# Altium schematic sheet 04-Balancing.SchDoc
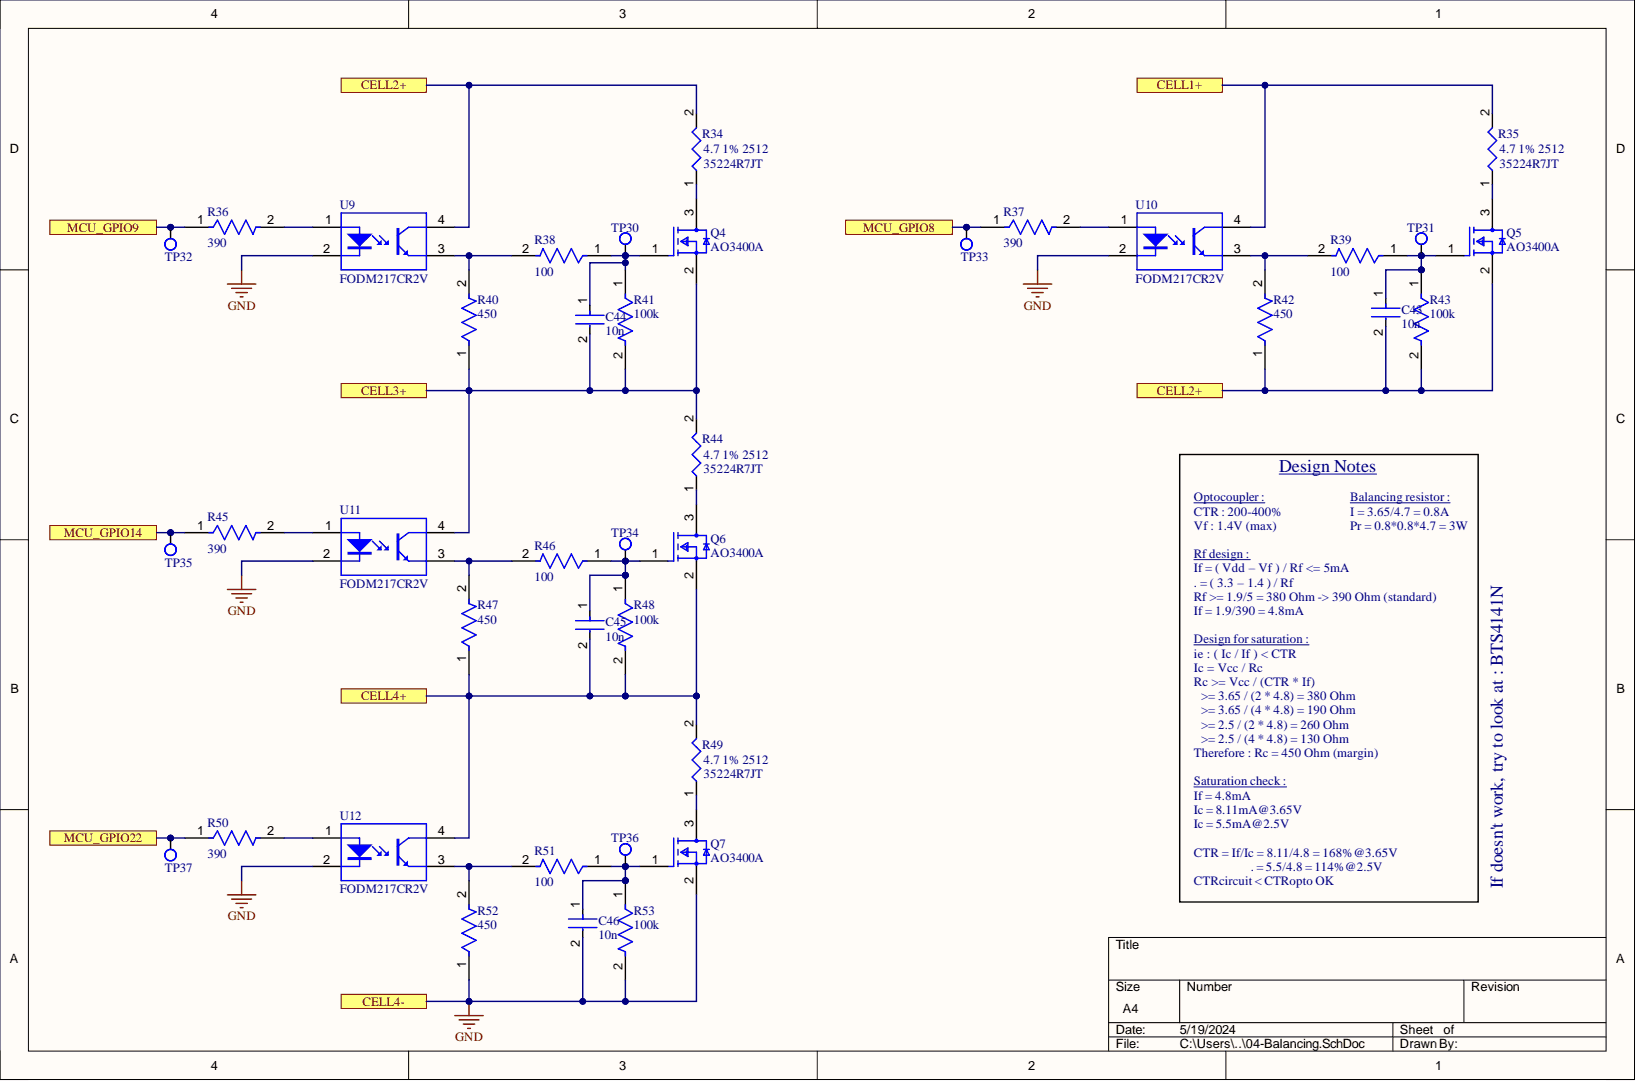
[diagram p0: 04-Balancing.SchDoc]

## Parts
C43  10n  [FP-CC0805-0_85-MFG]
C44  10n  [FP-CC0805-0_85-MFG]
C45  10n  [FP-CC0805-0_85-MFG]
C46  10n  [FP-CC0805-0_85-MFG]
Q4  AO3400A  [FP-SOT23-MFG]
Q5  AO3400A  [FP-SOT23-MFG]
Q6  AO3400A  [FP-SOT23-MFG]
Q7  AO3400A  [FP-SOT23-MFG]
R34  35224R7JT  value 4.7 1% 2512  [RESC6432X06N]
R35  35224R7JT  value 4.7 1% 2512  [RESC6432X06N]
R36  390  [FP-RT0805-MFG]
R37  390  [FP-RT0805-MFG]
R38  100  [FP-RT0805-MFG]
R39  100  [FP-RT0805-MFG]
R40  450  [FP-RT0805-MFG]
R41  100k  [FP-RT0805-MFG]
R42  450  [FP-RT0805-MFG]
R43  100k  [FP-RT0805-MFG]
R44  35224R7JT  value 4.7 1% 2512  [RESC6432X06N]
R45  390  [FP-RT0805-MFG]
R46  100  [FP-RT0805-MFG]
R47  450  [FP-RT0805-MFG]
R48  100k  [FP-RT0805-MFG]
R49  35224R7JT  value 4.7 1% 2512  [RESC6432X06N]
R50  390  [FP-RT0805-MFG]
R51  100  [FP-RT0805-MFG]
R52  450  [FP-RT0805-MFG]
R53  100k  [FP-RT0805-MFG]
TP30  Test point  [Test point-Footprint-1]  (value not drawn)
TP31  Test point  [Test point-Footprint-1]  (value not drawn)
TP32  Test point  [Test point-Footprint-1]  (value not drawn)
TP33  Test point  [Test point-Footprint-1]  (value not drawn)
TP34  Test point  [Test point-Footprint-1]  (value not drawn)
TP35  Test point  [Test point-Footprint-1]  (value not drawn)
TP36  Test point  [Test point-Footprint-1]  (value not drawn)
TP37  Test point  [Test point-Footprint-1]  (value not drawn)
U9  FODM217CR2V  [SOP127P680X239-4N]
U10  FODM217CR2V  [SOP127P680X239-4N]
U11  FODM217CR2V  [SOP127P680X239-4N]
U12  FODM217CR2V  [SOP127P680X239-4N]

## Ports
CELL1+, CELL2+, CELL3+, CELL4+, CELL4-, MCU_GPIO8, MCU_GPIO9, MCU_GPIO14, MCU_GPIO22

## Nets
GND: C46.2 Q7.2 R52.1 R53.2 U9.2 U10.2 U11.2 U12.2
CELL1+: R35.2 U10.4 ; port CELL1+
CELL2+: C43.2 Q5.2 R34.2 R42.1 R43.2 U9.4 ; port CELL2+
CELL3+: C44.2 Q4.2 R40.1 R41.2 R44.2 U11.4 ; port CELL3+
MCU_GPIO8: R37.1 TP33.1 ; port MCU_GPIO8
MCU_GPIO9: R36.1 TP32.1 ; port MCU_GPIO9
MCU_GPIO14: R45.1 TP35.1 ; port MCU_GPIO14
MCU_GPIO22: R50.1 TP37.1 ; port MCU_GPIO22
NetC43_1: C43.1 Q5.1 R39.1 R43.1 TP31.1
NetC44_1: C44.1 Q4.1 R38.1 R41.1 TP30.1
NetC45_1: C45.1 Q6.1 R46.1 R48.1 TP34.1
NetC45_2: C45.2 Q6.2 R47.1 R48.2 R49.2 U12.4
NetC46_1: C46.1 Q7.1 R51.1 R53.1 TP36.1
NetQ4_3: Q4.3 R34.1
NetQ5_3: Q5.3 R35.1
NetQ6_3: Q6.3 R44.1
NetQ7_3: Q7.3 R49.1
NetR36_2: R36.2 U9.1
NetR37_2: R37.2 U10.1
NetR38_2: R38.2 R40.2 U9.3
NetR39_2: R39.2 R42.2 U10.3
NetR45_2: R45.2 U11.1
NetR46_2: R46.2 R47.2 U11.3
NetR50_2: R50.2 U12.1
NetR51_2: R51.2 R52.2 U12.3

## Library data of the parts
C43-C46 = CMP-1036-04410-2: CAP CER 0.1UF 25V X7R 0805; Manufacturer: Yageo; Manufacturer Part Number: CC0805KRX7R8BB104; Capacitance: 100 nF; Material: Ceramic; Tolerance: 0.1; Value: 100 nF; Automotive: No; Category: Cap; Component Kind: Standard; ComponentLink2Description: Datasheet; ComponentLink2URL: http://www.yageo.com/documents/recent/UPY-GPHC_Y5V_6.3V-to-50V_8.pdf; Device Class L1: Passive Components; Device Class L2: Capacitors; Device Class L3: Ceramic Capacitors; Digikey Description: CAP CER 0.1UF 25V X7R 0805; Footprint Url: http://www.yageo.com/exep/pages/download/literatures/UPY-C_GEN_24.pdf; Height: 0.95mm; Ipc Land Pattern Name: CAPC200125X85; LCSC_Part_#: C1710; Lead Free: Yes; Library Name: Altium Content Vault; Mouser Description: Multilayer Ceramic Capacitors MLCC - SMD/SMT 100nF 25V X7R 10%; Octopart: https://octopart.com/cc0805krx7r8bb104-yageo-39467766; Package: CC0805-0.85; RoHS: TRUE; Temperature: -30°C to +85°C; Temperature Characteristic: X7R; Temperature Range High: +85°C; Temperature Range Low: -30°C; Voltage Rating: 25 V
Q4-Q7 = CMP-83955-000006-1: MOSFET N-CH 30V 5.7A SOT23; Gate to Source Voltage (Vgs): 12V; Input Capacitance: 630pF; Max Power Dissipation: 1.4W; Number of Elements: 1; Rds On Max: 26.5mR; Case/Package: SOT-23; Component Kind: Standard; Continuous Drain Current (ID): 5.7A; Drain to Source Voltage (Vdss): 30V; LCSC_Part_#: C20917; Lead Free: Lead Free; Library Name: Altium Content Vault; Max Operating Temperature: 150°C; Min Operating Temperature: -55°C; Mount: Surface Mount; Number of Pins: 3; Packaging: Tape and Reel; Power Dissipation: 1.4W; Radiation Hardening: No
R34 R35 R44 R49 = CMP-009-00177-1: Fixed Resistor, Metal Glaze/thick Film, 3W, 4.7ohm, 250V, 5% +/-Tol, 400ppm/Cel, Surface Mount, 2512; Case/Package: 2512; Component Kind: Standard; LCSC_Part_#: C3924519; Pins: 2; RoHS Compliant: Yes; Library Name: Clement Verda
R36-R43 R45-R48 R50-R53 = CMP-03412-005354-1: RES SMD 1K OHM 1% 1/8W 0805; Manufacturer: Yageo; Manufacturer Part Number: RT0805DRE074K7L; Power Rating: 0.125W; Resistance: 4.7KΩ; Temperature Coefficient: 50 ppm/°C; Tolerance: 0.01; Value: 4.7KΩ; Voltage Rating: 150V; Automotive: No; Category: Res; Component Kind: Standard; ComponentLink2Description: Datasheet; ComponentLink2URL: http://www.yageo.com/documents/recent/PYu-RT_1-to-0.01_RoHS_L_9.pdf; Composition: Thin Film; Device Class L1: Passive Components; Device Class L2: Resistors; Device Class L3: Chip SMD Resistors; Digikey Description: RES SMD 4.7K OHM 0.5% 1/8W 0805; Footprint Url: http://www.yageo.com/exep/pages/download/literatures/PYu-R_Mount_10.pdf; Height: 0.6mm; Ipc Land Pattern Name: RESC200125X50; Lead Free: Yes; Library Name: Altium Content Vault; Octopart: https://octopart.com/rt0805dre074k7l-yageo-1242027; Package: RT0805; RoHS: TRUE; Series: RT; Temperature: -55°C to +155°C; Temperature Range High: +155°C; Temperature Range Low: -55°C
  R36 R37 R45 R50: LCSC_Part_#: C17655
  R38 R39 R46 R51: LCSC_Part_#: C17408
  R40 R42 R47 R52: LCSC_Part_#: C865503
  R41 R43 R48 R53: LCSC_Part_#: C149504
TP30-TP37 = CMP-002-00075-1: Component Kind: Standard; Description: test point; Library Name: Clement Verda
U9-U12 = CMP-00026-00001-1: Transistor Output Optocoupler, 1-Element, 3750V Isolation; Component Kind: Standard; LCSC_Part_#: C891189; RoHS Compliant: Yes; Library Name: Clement Verda
